# Altium schematic sheet MCU.SchDoc
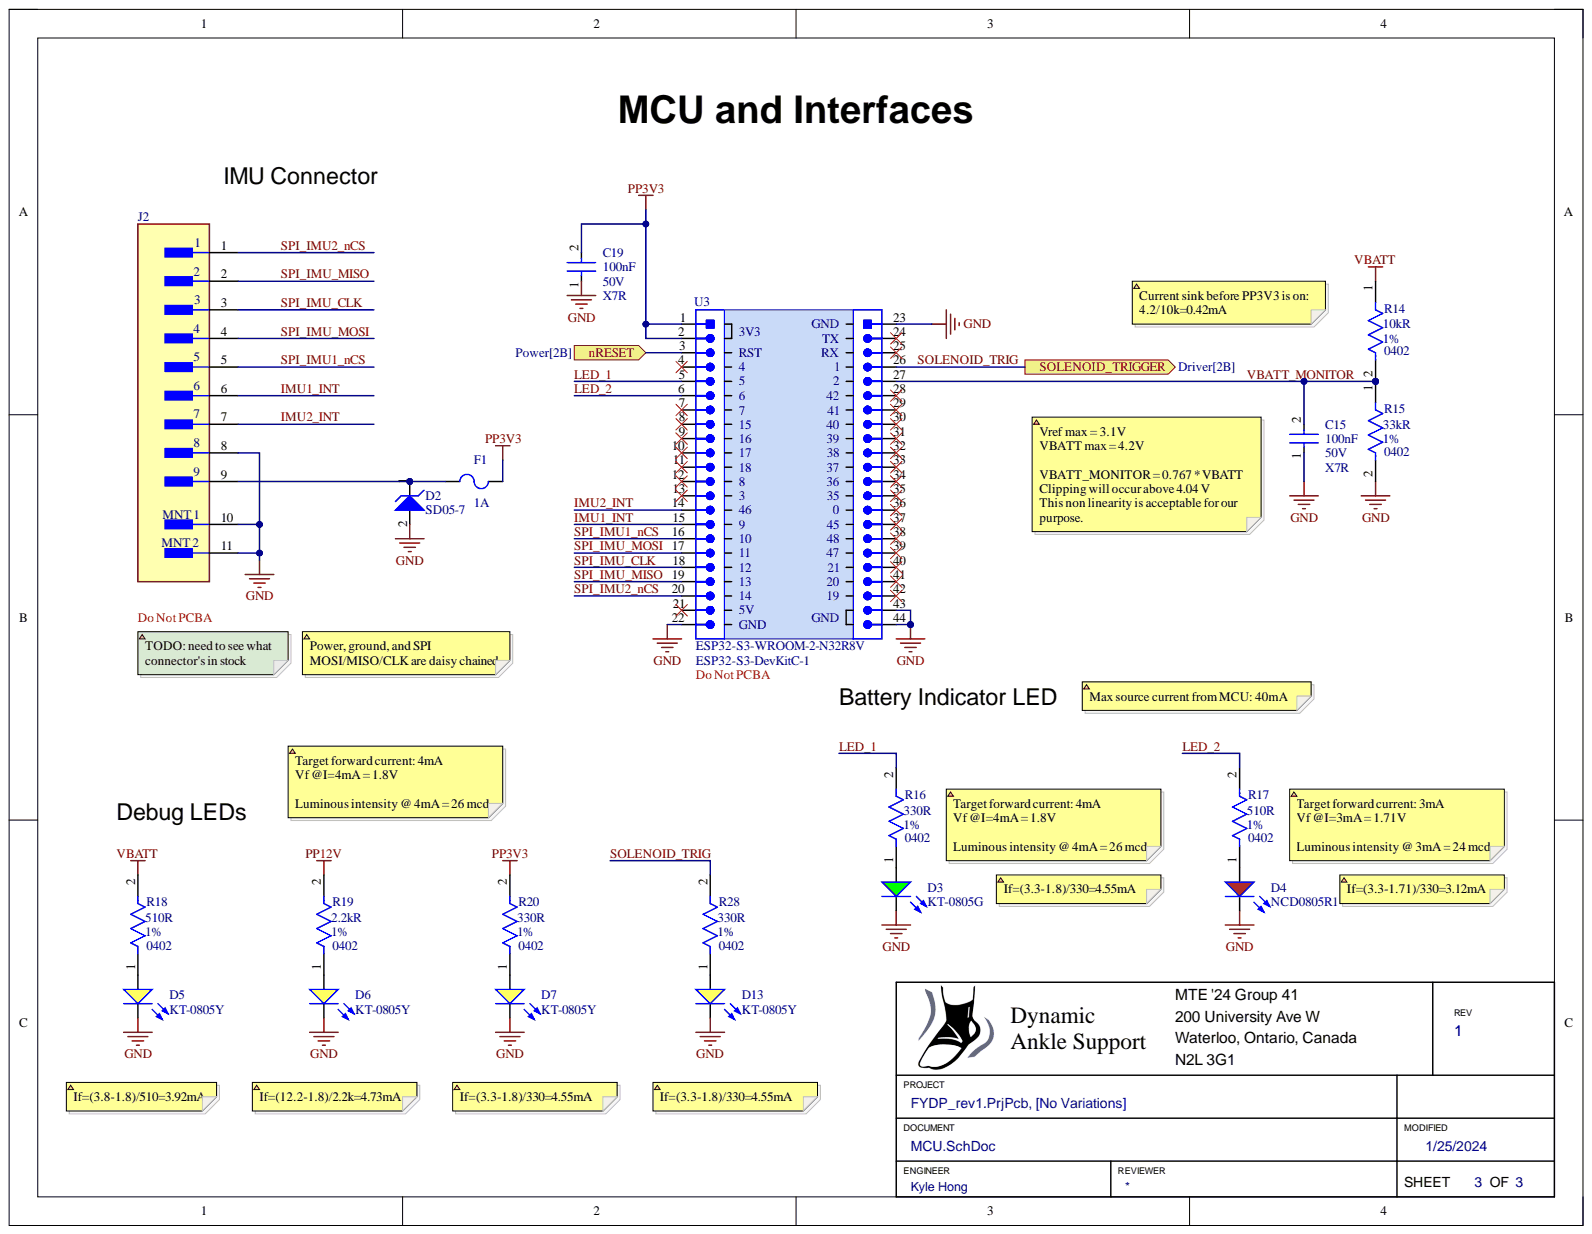
[diagram p0: MCU.SchDoc]

## Parts
C15  Capacitance: 100nF  Dielectric: X7R  Voltage Rating: 50V  [CAP0402]  (hidden comment: CL05B104KB54PNC)
C19  Capacitance: 100nF  Dielectric: X7R  Voltage Rating: 50V  [CAP0402]  (hidden comment: CL05B104KB54PNC)
D2  SD05-7  [SD05-7]
D3  KT-0805G  [LED_0805_GREEN]
D4  NCD0805R1  [LED_0805_RED]
D5  KT-0805Y  [LED_0805_YELLOW]
D6  KT-0805Y  [LED_0805_YELLOW]
D7  KT-0805Y  [LED_0805_YELLOW]
D13  KT-0805Y  [LED_0805_YELLOW]
F1  Current Rating: 1A  [FUSE_0603]  (hidden comment: 0467001.NRHF)
J2  S9B-PH-SM4-TB(LF)(SN)  [FP-S9B-PH-SM4-TB_LF_SN-MFG]  (value not drawn)
R14  Package: 0402  Resistance: 10kR  Tolerance: 1%  [RES0402]  (hidden comment: 0402WGF1002TCE)
R15  Package: 0402  Resistance: 33kR  Tolerance: 1%  [RES0402]  (hidden comment: 0402WGF3302TCE)
R16  Package: 0402  Resistance: 330R  Tolerance: 1%  [RES0402]  (hidden comment: 0402WGF3300TCE)
R17  Package: 0402  Resistance: 510R  Tolerance: 1%  [RES0402]  (hidden comment: 0402WGF5100TCE)
R18  Package: 0402  Resistance: 510R  Tolerance: 1%  [RES0402]  (hidden comment: 0402WGF5100TCE)
R19  Package: 0402  Resistance: 2.2kR  Tolerance: 1%  [RES0402]  (hidden comment: 0402WGF2201TCE)
R20  Package: 0402  Resistance: 330R  Tolerance: 1%  [RES0402]  (hidden comment: 0402WGF3300TCE)
R28  Package: 0402  Resistance: 330R  Tolerance: 1%  [RES0402]  (hidden comment: 0402WGF3300TCE)
U3  ESP32-S3-WROOM-2-N32R8V  Dev Kit Part Number: ESP32-S3-DevKitC-1  [EPS32-S3-DevKitC]

## Ports
nRESET, SOLENOID_TRIGGER

## Nets
GND: C15.1 C19.1 D2.2 D3.1 D4.1 D5.1 D6.1 D7.1 D13.1 J2.8 J2.10 J2.11 R15.2 U3.22 U3.23 U3.43 U3.44
PP3V3: C19.2 F1.2 R20.2 U3.1 U3.2
PP12V: R19.2
VBATT: R14.1 R18.2
IMU1_INT: J2.6 U3.15
IMU2_INT: J2.7 U3.14
LED_1: R16.2 U3.5
LED_2: R17.2 U3.6
nRESET: U3.3 ; port nRESET
SOLENOID_TRIG: R28.2 U3.26 ; port SOLENOID_TRIGGER
SPI_IMU1_nCS: J2.5 U3.16
SPI_IMU2_nCS: J2.1 U3.20
SPI_IMU_CLK: J2.3 U3.18
SPI_IMU_MISO: J2.2 U3.19
SPI_IMU_MOSI: J2.4 U3.17
VBATT_MONITOR: C15.2 R14.2 R15.1 U3.27
NetD2_1: D2.1 F1.1 J2.9
NetD3_2: D3.2 R16.1
NetD4_2: D4.2 R17.1
NetD5_2: D5.2 R18.1
NetD6_2: D6.2 R19.1
NetD7_2: D7.2 R20.1
NetD13_2: D13.2 R28.1

Not connected: U3 4 7 8 9 10 11 12 13 21 24 25 28 29 30 31 32 33 34 35 36 37 38 39 40 41 42

## Library data of the parts
C15 C19 = CAP0402: 50V 100nF X7R ±10% 0402  Multilayer Ceramic Capacitors MLCC - SMD/SMT ROHS; Manufacturer: Samsung Electro-Mechanics; Tolerance: ±20%; Component Kind: Standard; Manufacturer Part Number: CL05B104KB54PNC; Package: 0402; Library Name: FYDP_library_sch.SchLib
D2 = SD05-7: TVS UNI14.5V 6.2V 5V SOD-323; Manufacturer: Diodes Incorporated; Clamping Voltage: 14.5V; Breakdown Voltage: 6.2V; Component Kind: Standard; Manufacturer Part Number: SD05-7; Package: SOD-323; Reverse Standoff Voltage: 5V; Library Name: FYDP_library_sch.SchLib
D3 = LED_GREEN: Emerald 0805  Light Emitting Diodes (LED) ROHS; Manufacturer: Hubei KENTO Elec; If rated: 20mA; Vf: 2.1V; Component Kind: Standard; Manufacturer Part Number: KT-0805G; Library Name: FYDP_library_sch.SchLib
D4 = LED_RED: Colorless transparence 67mcd~195mcd -30℃~+85℃ 615nm~630nm Red 130° 65mW 0805  Light Emitting Diodes (LED) ROHS; Manufacturer: Foshan NationStar Optoelectronics; If rated: 25mA; Vf: 2.0V; Component Kind: Standard; Manufacturer Part Number: NCD0805R1; Library Name: FYDP_library_sch.SchLib
D5-D7 D13 = LED_YELLOW: Yellow 0805  Light Emitting Diodes (LED) ROHS; Manufacturer: Hubei KENTO Elec; If rated: 20mA; Vf: 2.1V; Component Kind: Standard; Manufacturer Part Number: KT-0805Y; Library Name: FYDP_library_sch.SchLib
F1 = FUSE0603: 50A SMD Fuse 1A 32V 32V 0603  Fuses ROHS; Manufacturer: Littelfuse; Component Kind: Standard; Manufacturer Part Number: 0467001.NRHF; Package: 0603; Supplier 1: Future Electronics; Supplier Part Number 1: 6130137; Voltage Rating: 32V; Library Name: FYDP_library_sch.SchLib
J2 = CMP-17439-000494-1: CONN HEADER SMD R/A 9POS 2MM; Contact Resistance: 10mR; Current Rating: 2A; Gender: Male; Insulation Resistance: 1GR; Number of Contacts: 9; Voltage Rating: 100V; Color: White; Component Kind: Standard; Connector Type: Header; Contact Finish - Mating: TIN; Contact Material: Copper Alloy; Contact Mating Length: 0.13inch; Contact Plating: Tin; Contact Shape: Square; Contact Type: Male Pin; Fastening Type: Detent; Flammability Rating: UL94 V-0; Housing Color: Natural; Housing Material: Nylon; Insulation Color: Natural; Insulation Material: Nylon; Lead Free: Lead Free; Lead Pitch: 2mm; Library Name: Altium Content Vault; Max Operating Temperature: 85°C; Min Operating Temperature: -25°C; Mount: Surface Mount; Number of Poles: 9; Number of Positions: 9; Number of Rows: 1; Orientation: Right Angle; Packaging: Cut Tape; Pitch: 2mm; Reach SVHC Compliant: Yes; Series: PH; Termination: Solder; Voltage Rating (AC): 100V; Working Voltage: 100V
R14 = RES0402: 62.5mW Thick Film Resistors ±100ppm/℃ ±1% 10kΩ 0402  Chip Resistor - Surface Mount ROHS; Manufacturer: UNI-ROYAL(Uniroyal Elec); Component Kind: Standard; Manufacturer Part Number: 0402WGF1002TCE; Power Rating: 62.5mW; Library Name: FYDP_library_sch.SchLib
R15 = RES0402: 62.5mW Thick Film Resistors ±100ppm/℃ ±1% 33kΩ 0402  Chip Resistor - Surface Mount ROHS; Manufacturer: UNI-ROYAL(Uniroyal Elec); Component Kind: Standard; Manufacturer Part Number: 0402WGF3302TCE; Power Rating: 62.5mW; Library Name: FYDP_library_sch.SchLib
R16 R20 R28 = RES0402: 62.5mW Thick Film Resistors ±100ppm/℃ ±1% 330Ω 0402  Chip Resistor - Surface Mount ROHS; Manufacturer: UNI-ROYAL(Uniroyal Elec); Component Kind: Standard; Manufacturer Part Number: 0402WGF3300TCE; Power Rating: 62.5mW; Library Name: FYDP_library_sch.SchLib
R17 R18 = RES0402: 62.5mW Thick Film Resistors ±100ppm/℃ ±1% 510Ω 0402  Chip Resistor - Surface Mount ROHS; Manufacturer: UNI-ROYAL(Uniroyal Elec); Component Kind: Standard; Manufacturer Part Number: 0402WGF5100TCE; Power Rating: 62.5mW; Library Name: FYDP_library_sch.SchLib
R19 = RES0402: 62.5mW Thick Film Resistors ±100ppm/℃ ±1% 2.2kΩ 0402  Chip Resistor - Surface Mount ROHS; Manufacturer: UNI-ROYAL(Uniroyal Elec); Component Kind: Standard; Manufacturer Part Number: 0402WGF2201TCE; Power Rating: 62.5mW; Library Name: FYDP_library_sch.SchLib
U3 = EPS32-S3-DevKitC: Component Kind: Standard; MCU Part Number: ESP32-S3-WROOM-2-N32R8V; Library Name: FYDP_library_sch.SchLib
